# Altium schematic sheet Sheet2.SchDoc(Sheet2)
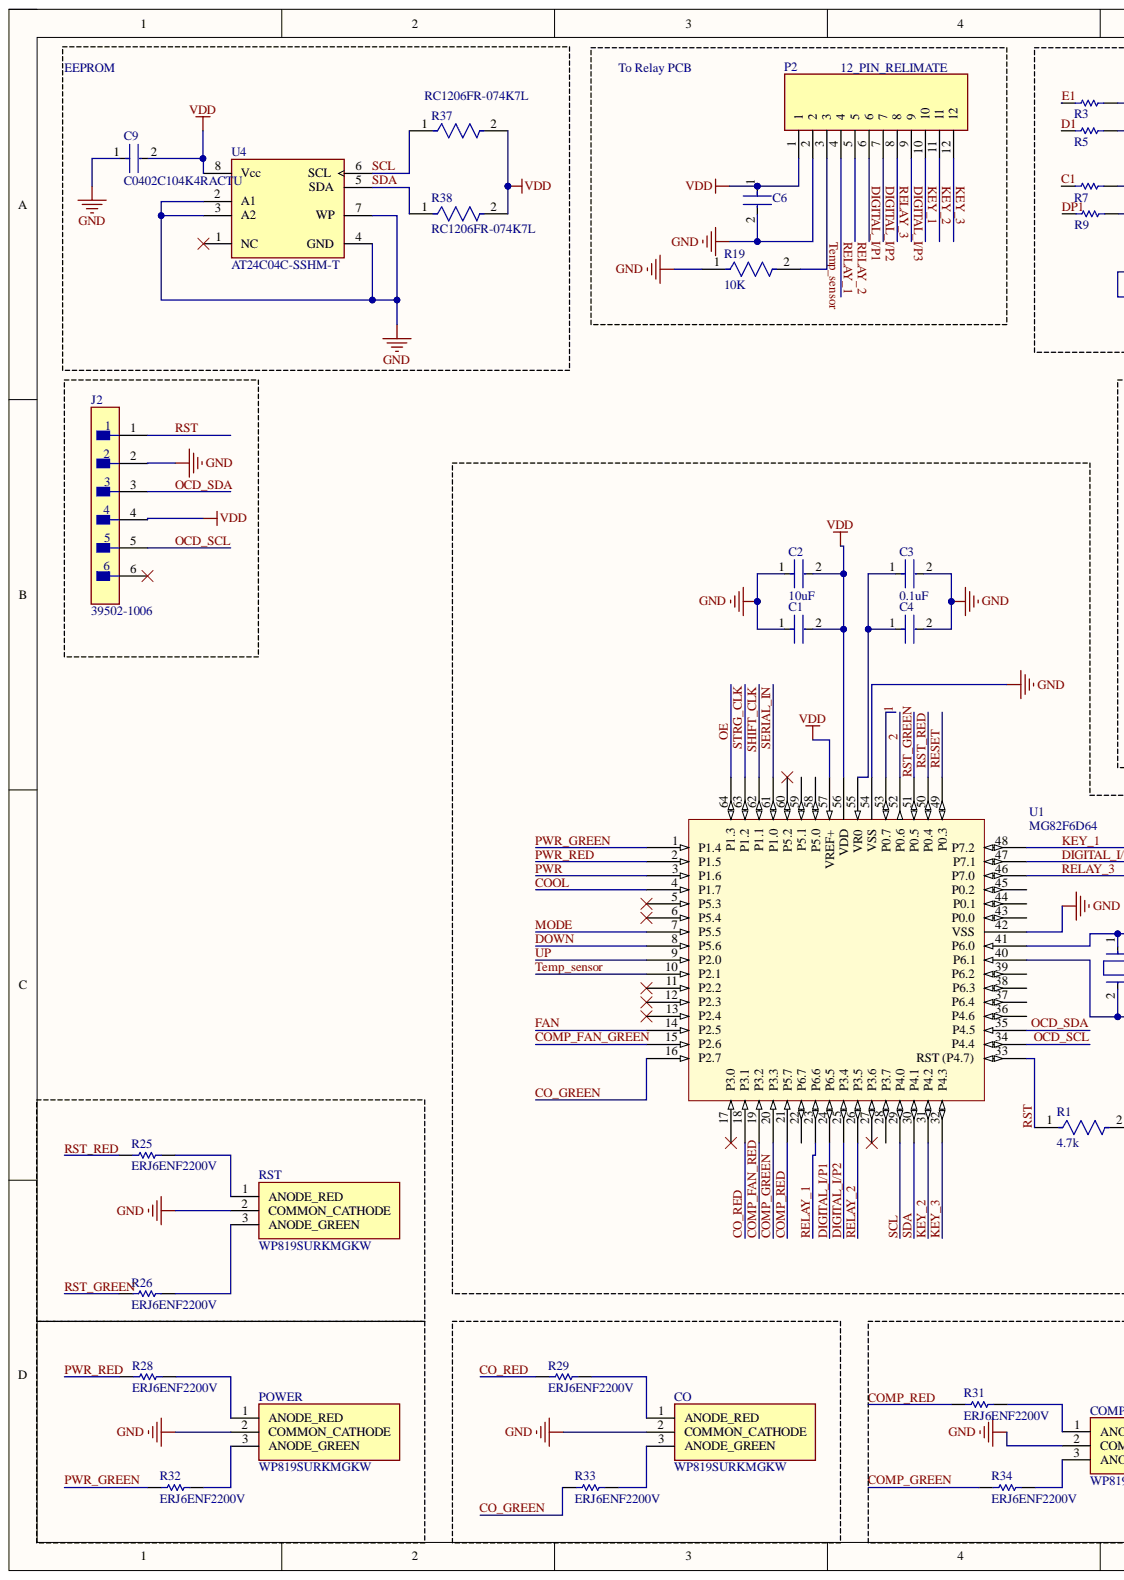
[diagram p0: Sheet2.SchDoc, left]
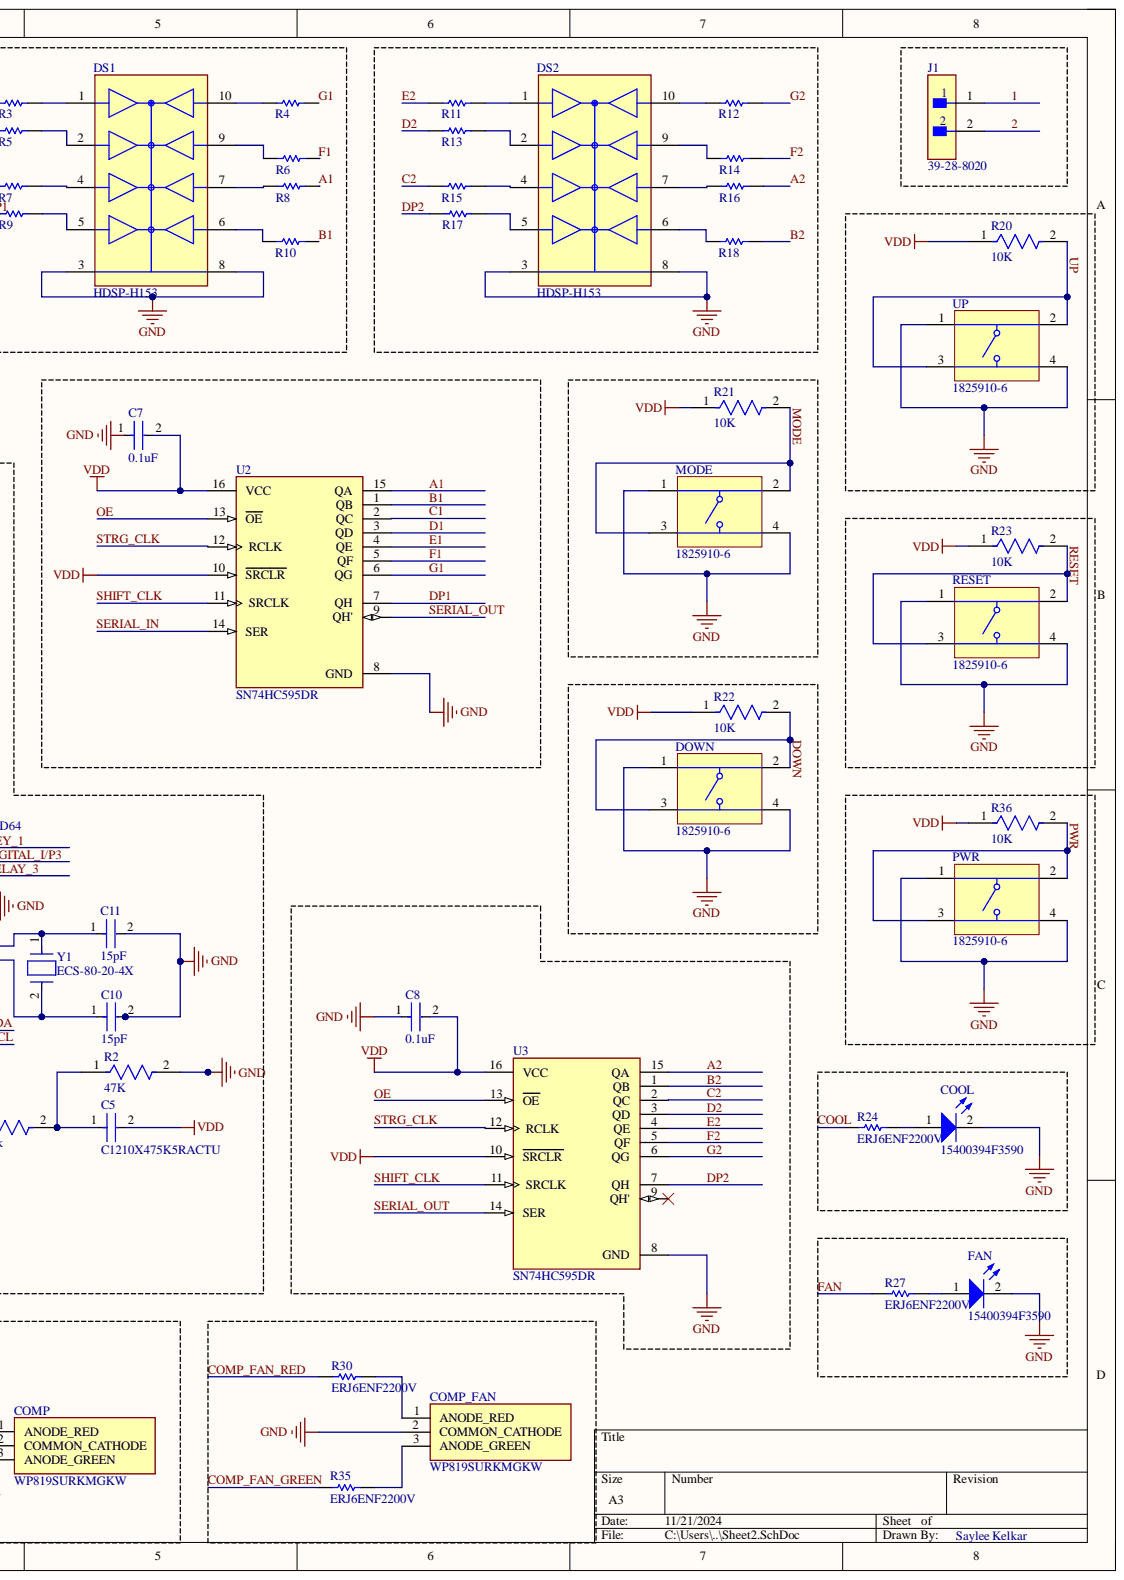
[diagram p1: Sheet2.SchDoc, right]

## Parts
C1  C0402C104K4RACTU  [FP-C0402-BB-MFG]
C2  10uF  [FP-GRM21B-0_15-IPC_C]
C3  0.1uF  [FP-C0402-BB-MFG]
C4  C0402C104K4RACTU  [FP-C0402-BB-MFG]
C5  C1210X475K5RACTU  [FP-C1210X475K5RACTU-MFG]
C6  C3216X5R1E476M160AC  [FP-C3216-160-0_2-MFG]  (value not drawn)
C7  0.1uF  [FP-C0402-BB-MFG]
C8  0.1uF  [FP-C0402-BB-MFG]
C9  C0402C104K4RACTU  [FP-C0402-BB-MFG]
C10  15pF  [FP-C1206C-EB-MFG]
C11  15pF  [FP-C1206C-EB-MFG]
CO  WP819SURKMGKW  [WP819SURKMGKW]
COMP  WP819SURKMGKW  [WP819SURKMGKW]
COMP_FAN  WP819SURKMGKW  [WP819SURKMGKW]
COOL  15400394F3590  [WL-TIRC_3mm]
DOWN  1825910-6  [TECO-1825910-6_V]
DS1  HDSP-H153  [FP-HDSP-H153-MFG]
DS2  HDSP-H153  [FP-HDSP-H153-MFG]
FAN  15400394F3590  [WL-TIRC_3mm]
J1  39-28-8020  [FP-39-28-8020-MFG]
J2  39502-1006  [FP-39502-1006-MFG]
MODE  1825910-6  [TECO-1825910-6_V]
P2  12_PIN_RELIMATE  [TE_1-644752-2]
POWER  WP819SURKMGKW  [WP819SURKMGKW]
PWR  1825910-6  [TECO-1825910-6_V]
R1  4.7k  [FP-CRCW0603-e3-IPC_A]
R2  47K  [FP-CRCW0603-e3-IPC_B]
R3  560 Ohm  [RESC1005X40X25LL05T05]  (value not drawn)
R4  560 Ohm  [RESC1005X40X25LL05T05]  (value not drawn)
R5  560 Ohm  [RESC1005X40X25LL05T05]  (value not drawn)
R6  560 Ohm  [RESC1005X40X25LL05T05]  (value not drawn)
R7  560 Ohm  [RESC1005X40X25LL05T05]  (value not drawn)
R8  560 Ohm  [RESC1005X40X25LL05T05]  (value not drawn)
R9  560 Ohm  [RESC1005X40X25LL05T05]  (value not drawn)
R10  560 Ohm  [RESC1005X40X25LL05T05]  (value not drawn)
R11  560 Ohm  [RESC1005X40X25LL05T05]  (value not drawn)
R12  560 Ohm  [RESC1005X40X25LL05T05]  (value not drawn)
R13  560 Ohm  [RESC1005X40X25LL05T05]  (value not drawn)
R14  560 Ohm  [RESC1005X40X25LL05T05]  (value not drawn)
R15  560 Ohm  [RESC1005X40X25LL05T05]  (value not drawn)
R16  560 Ohm  [RESC1005X40X25LL05T05]  (value not drawn)
R17  560 Ohm  [RESC1005X40X25LL05T05]  (value not drawn)
R18  560 Ohm  [RESC1005X40X25LL05T05]  (value not drawn)
R19  10K  [FP-CRCW0402-e3-IPC_B]
R20  10K  [FP-CRCW0402-e3-IPC_B]
R21  10K  [FP-CRCW0402-e3-IPC_B]
R22  10K  [FP-CRCW0402-e3-IPC_B]
R23  10K  [FP-CRCW0402-e3-IPC_B]
R24  ERJ6ENF2200V  [RESC2013X70X40NL20T20]
R25  ERJ6ENF2200V  [RESC2013X70X40NL20T20]
R26  ERJ6ENF2200V  [RESC2013X70X40NL20T20]
R27  ERJ6ENF2200V  [RESC2013X70X40NL20T20]
R28  ERJ6ENF2200V  [RESC2013X70X40NL20T20]
R29  ERJ6ENF2200V  [RESC2013X70X40NL20T20]
R30  ERJ6ENF2200V  [RESC2013X70X40NL20T20]
R31  ERJ6ENF2200V  [RESC2013X70X40NL20T20]
R32  ERJ6ENF2200V  [RESC2013X70X40NL20T20]
R33  ERJ6ENF2200V  [RESC2013X70X40NL20T20]
R34  ERJ6ENF2200V  [RESC2013X70X40NL20T20]
R35  ERJ6ENF2200V  [RESC2013X70X40NL20T20]
R36  10K  [FP-CRCW0402-e3-IPC_B]
R37  RC1206FR-074K7L  [FP-RC1206-0_65-IPC_B]
R38  RC1206FR-074K7L  [FP-RC1206-0_65-IPC_B]
RESET  1825910-6  [TECO-1825910-6_V]
RST  WP819SURKMGKW  [WP819SURKMGKW]
U1  MG82F6D64  [Quad64]
U2  SN74HC595DR  [FP-D0016A-MFG]
U3  SN74HC595DR  [FP-D0016A-MFG]
U4  AT24C04C-SSHM-T  [FP-C04-057-SN-MFG]
UP  1825910-6  [TECO-1825910-6_V]
Y1  ECS-80-20-4X  [FP-ECS-80-20-4X-MFG]

## Nets
GND: C1.1 C2.1 C3.2 C4.2 C6.2 C7.1 C8.1 C9.1 C10.2 C11.2 CO.2 COMP.2 COMP_FAN.2 COOL.2 DOWN.1 DOWN.4 DS1.3 DS1.8 DS2.3 DS2.8 FAN.2 J2.2 MODE.1 MODE.4 P2.2 POWER.2 PWR.1 PWR.4 R2.2 R19.1 RESET.1 RESET.4 RST.2 U1.42(VSS) U1.54(VSS) U2.8(GND) U3.8(GND) U4.2(A1) U4.3(A2) U4.4(GND) U4.7(WP) UP.1 UP.4
VDD: C1.2 C2.2 C5.2 C6.1 C7.2 C8.2 C9.2 J2.4 P2.1 R20.1 R21.1 R22.1 R23.1 R36.1 R37.2 R38.2 U1.56(VDD) U1.57(VREF+) U2.10(SRCLR) U2.16(VCC) U3.10(SRCLR) U3.16(VCC) U4.8(Vcc)
1: J1.1 U1.53(P0.7)
2: J1.2 U1.52(P0.6)
A1: R8.2 U2.15(QA)
A2: R16.2 U3.15(QA)
B1: R10.2 U2.1(QB)
B2: R18.2 U3.1(QB)
C1: R7.1 U2.2(QC)
C2: R15.1 U3.2(QC)
CO_GREEN: R33.1 U1.16(P2.7)
CO_RED: R29.1 U1.18(P3.1)
COMP_FAN_GREEN: R35.1 U1.15(P2.6)
COMP_FAN_RED: R30.1 U1.19(P3.2)
COMP_GREEN: R34.1 U1.20(P3.3)
COMP_RED: R31.1 U1.21(P5.7)
COOL: R24.1 U1.4(P1.7)
D1: R5.1 U2.3(QD)
D2: R13.1 U3.3(QD)
DIGITAL_I/P1: P2.6 U1.24(P6.5)
DIGITAL_I/P2: P2.7 U1.25(P3.4)
DIGITAL_I/P3: P2.9 U1.47(P7.1)
DOWN: DOWN.2 DOWN.3 R22.2 U1.8(P5.6)
DP1: R9.1 U2.7(QH)
DP2: R17.1 U3.7(QH)
E1: R3.1 U2.4(QE)
E2: R11.1 U3.4(QE)
F1: R6.2 U2.5(QF)
F2: R14.2 U3.5(QF)
FAN: R27.1 U1.14(P2.5)
G1: R4.2 U2.6(QG)
G2: R12.2 U3.6(QG)
KEY_1: P2.10 U1.48(P7.2)
KEY_2: P2.11 U1.31(P4.2)
KEY_3: P2.12 U1.32(P4.3)
MODE: MODE.2 MODE.3 R21.2 U1.7(P5.5)
OCD_SCL: J2.5 U1.34(P4.4)
OCD_SDA: J2.3 U1.35(P4.5)
OE: U1.64(P1.3) U2.13(OE) U3.13(OE)
PWR: PWR.2 PWR.3 R36.2 U1.3(P1.6)
PWR_GREEN: R32.1 U1.1(P1.4)
PWR_RED: R28.1 U1.2(P1.5)
RELAY_1: P2.4 U1.23(P6.6)
RELAY_2: P2.5 U1.26(P3.5)
RELAY_3: P2.8 U1.46(P7.0)
RESET: R23.2 RESET.2 RESET.3 U1.49(P0.3)
RST: J2.1 R1.1 U1.33(RST_(P4.7))
RST_GREEN: R26.1 U1.51(P0.5)
RST_RED: R25.1 U1.50(P0.4)
SCL: R37.1 U1.29(P4.0) U4.6(SCL)
SDA: R38.1 U1.30(P4.1) U4.5(SDA)
SERIAL_IN: U1.61(P1.0) U2.14(SER)
SERIAL_OUT: U2.9(QH') U3.14(SER)
SHIFT_CLK: U1.62(P1.1) U2.11(SRCLK) U3.11(SRCLK)
STRG_CLK: U1.63(P1.2) U2.12(RCLK) U3.12(RCLK)
Temp_sensor: P2.3 R19.2 U1.10(P2.1)
UP: R20.2 U1.9(P2.0) UP.2 UP.3
NetC3_1: C3.1 C4.1 U1.55(VR0)
NetC5_1: C5.1 R1.2 R2.1
NetC10_1: C10.1 U1.40(P6.1) Y1.2
NetC11_1: C11.1 U1.41(P6.0) Y1.1
NetCO_1: CO.1 R29.2
NetCO_3: CO.3 R33.2
NetCOMP_1: COMP.1 R31.2
NetCOMP_3: COMP.3 R34.2
NetCOMP_FAN_1: COMP_FAN.1 R30.2
NetCOMP_FAN_3: COMP_FAN.3 R35.2
NetCOOL_1: COOL.1 R24.2
NetDS1_1: DS1.1 R3.2
NetDS1_2: DS1.2 R5.2
NetDS1_4: DS1.4 R7.2
NetDS1_5: DS1.5 R9.2
NetDS1_6: DS1.6 R10.1
NetDS1_7: DS1.7 R8.1
NetDS1_9: DS1.9 R6.1
NetDS1_10: DS1.10 R4.1
NetDS2_1: DS2.1 R11.2
NetDS2_2: DS2.2 R13.2
NetDS2_4: DS2.4 R15.2
NetDS2_5: DS2.5 R17.2
NetDS2_6: DS2.6 R18.1
NetDS2_7: DS2.7 R16.1
NetDS2_9: DS2.9 R14.1
NetDS2_10: DS2.10 R12.1
NetFAN_1: FAN.1 R27.2
NetPOWER_1: POWER.1 R28.2
NetPOWER_3: POWER.3 R32.2
NetR25_2: R25.2 RST.1
NetR26_2: R26.2 RST.3

Not connected: J2 6; U1 5(P5.3) 6(P5.4) 11(P2.2) 12(P2.3) 13(P2.4) 17(P3.0) 22(P6.7) 27(P3.6) 28(P3.7) 36(P4.6) 37(P6.4) 38(P6.3) 39(P6.2) 43(P0.0) 44(P0.1) 45(P0.2) 58(P5.0) 59(P5.1) 60(P5.2); U3 9(QH'); U4 1(NC)

## Library data of the parts
C1 C4 C9 = CMP-2008-02108-2: CAP CER 0.1UF 16V X7R 0402; Manufacturer: Kemet; Capacitance: 0.10 μF; Material: Ceramic; Temperature Coefficient: 15%; Tolerance: 0.1; Value: 0.10 μF; Automotive: No; Category: Cap; Component Kind: Standard; ComponentLink2Description: Datasheet; ComponentLink2URL: https://content.kemet.com/datasheets/KEM_C1002_X7R_SMD.pdf; Device Class L1: Passive Components; Device Class L2: Capacitors; Device Class L3: Ceramic Capacitors; Digikey Description: CAP CER 0.1UF 16V X7R 0402; Height: 0.55mm; Ipc Land Pattern Name: CAPC10050X50; Lead Free: Yes; Library Name: Altium Content Vault; Manufacturer Part Number: C0402C104K4RACTU; Mouser Description: Cap Ceramic 0.1uF 16V X7R 10% SMD 0402 125?C Paper T/R - Tape and Reel (Alt: C0402C104K4RAC TU); Octopart: https://octopart.com/c0402c104k4ractu-kemet-94158; Package: C0402-BB; RoHS: TRUE; Temperature: -55°C to +125°C; Temperature Characteristic: X7R; Temperature Range High: +125°C; Temperature Range Low: -55°C; Voltage Rating: 16 V
C2 = CMP-2007-03541-2: Chip Multilayer Ceramic Capacitors for General Purpose, 0805, 10uF, X5R, 15%, 10%, 25V; Capacitance: 10uF; Case Code (Imperial): 0805; Case Code (Metric): 2012; Case/Package: 0805; Component Kind: Standard; Depth: 1.25mm; Dielectric: X5R; Height: 1.25mm; Lead Free: Lead Free; Length: 2mm; Library Name: Altium Content Vault; Material: Ceramic; Max Operating Temperature: 85°C; Min Operating Temperature: -55°C; Mount: Surface Mount; Package Quantity: 1; Packaging: Tape and Reel; Radiation Hardening: No; Termination: SMD/SMT; Thickness: 0.053inch; Tolerance: 10%; Voltage: 25V; Voltage Rating: 25V; Voltage Rating (DC): 25V; Width: 0.049inch
C3 C7 C8 = CMP-2008-02108-2: CAP CER 0.1UF 16V X7R 0402; Manufacturer: Kemet; Manufacturer Part Number: C0402C104K4RACTU; Capacitance: 0.10 μF; Material: Ceramic; Temperature Coefficient: 15%; Tolerance: 0.1; Value: 0.10 μF; Automotive: No; Category: Cap; Component Kind: Standard; ComponentLink2Description: Datasheet; ComponentLink2URL: https://content.kemet.com/datasheets/KEM_C1002_X7R_SMD.pdf; Device Class L1: Passive Components; Device Class L2: Capacitors; Device Class L3: Ceramic Capacitors; Digikey Description: CAP CER 0.1UF 16V X7R 0402; Height: 0.55mm; Ipc Land Pattern Name: CAPC10050X50; Lead Free: Yes; Library Name: Altium Content Vault; Mouser Description: Cap Ceramic 0.1uF 16V X7R 10% SMD 0402 125?C Paper T/R - Tape and Reel (Alt: C0402C104K4RAC TU); Octopart: https://octopart.com/c0402c104k4ractu-kemet-94158; Package: C0402-BB; RoHS: TRUE; Temperature: -55°C to +125°C; Temperature Characteristic: X7R; Temperature Range High: +125°C; Temperature Range Low: -55°C; Voltage Rating: 16 V
C5 = CMP-2000-06022-2: CAP CER 4.7UF 50V X7R 1210; Dielectric Material: Ceramic; Dissipation Factor: 2.5%; Tolerance: 10%; Capacitance: 4.7uF; Case Code (Imperial): 1210; Case Code (Metric): 3225; Case/Package: 1210; Component Kind: Standard; Construction: Flat; Depth: 2.5mm; Dielectric: X7R; Features: Soft Termination; Height: 2.5mm; Length: 3.3mm; Library Name: Altium Content Vault; Max Operating Temperature: 125°C; Min Operating Temperature: -55°C; Mount: Surface Mount; Number of Pins: 2; Package Quantity: 1000; Packaging: Tape and Reel; Radiation Hardening: No; REACH SVHC: No SVHC; RoHS Compliant: Yes; Series: FT-CAP; Termination: SMD/SMT; Thickness: 0.11inch; Voltage: 50V; Voltage Rating: 50V; Voltage Rating (DC): 50V; Width: 2.5mm
C6 = CMP-2000-05397-3: Multilayer Ceramic Capacitors 47uF ±20% 25V X5R SMD 1206; Capacitance: 47uF; Case Code (Imperial): 1206; Case Code (Metric): 3216; Case/Package: 1206; Component Kind: Standard; Depth: 1.6mm; Dielectric: X5R; Dielectric Material: Ceramic; Height: 1.6mm; Lead Free: Lead Free; Length: 0.126inch; Library Name: Altium Content Vault; Max Operating Temperature: 85°C; Min Operating Temperature: -55°C; Mount: Surface Mount; Package Quantity: 1; Packaging: Tape and Reel; REACH SVHC: No SVHC; Resistor Type: Ceramic; RoHS Compliant: Yes; Series: C; Termination: SMD/SMT; Thickness: 0.071inch; Tolerance: 20%; Voltage: 25V; Voltage Rating: 25V; Voltage Rating (DC): 25V; Weight: 0.000571oz; Width: 0.063inch
C10 C11 = CMP-1037-00805-2: 1206 C 15pF Ceramic Multilayer Capacitor; 50 VDC; +125degC; C0G Dielec; +/-5%; Manufacturer: KEMET; Manufacturer Part Number: C1206C150J5GACTU; Automotive Grade: Grade 0; Temperature Coefficient: 15%; Tolerance: 0.05; Voltage Rating: 50 V; Automotive: Yes; Capacitance: 15 pF; Category: Cap; Component Kind: Standard; ComponentLink2Description: Datasheet; ComponentLink2URL: https://content.kemet.com/datasheets/KEM_C1007_X8R_ULTRA_150C_SMD.pdf; Device Class L1: Passive Components; Device Class L2: Capacitors; Device Class L3: Ceramic Capacitors; Digikey Description: 1206 C 15pF Ceramic Multilayer Capacitor; 50 VDC; +125degC; C0G Dielec; +/-5%; Height: 0.88mm; Ipc Land Pattern Name: CAPC320160X78; Lead Free: Yes; Library Name: Altium Content Vault; Material: Ceramic; Mouser Description: Multilayer Ceramic Capacitors MLCC - SMD/SMT 50volts 15pF C0G 5%; Octopart: https://octopart.com/c1206c150j5gactu-kemet-138585; Package: C1206C-EB; RoHS: TRUE; Temperature: -55°C to +150°C; Temperature Characteristic: C0G; Temperature Range High: +150°C; Temperature Range Low: -55°C; Value: 15 pF
CO COMP COMP_FAN POWER RST = WP819SURKMGKW: Standard LEDs - Through Hole Red Green 630 570nm Diffused 750 130mcd; Manufacturer_Name: Kingbright; Geometry.Height: 14.75mm; Component Kind: Standard; Datasheet Link: https://www.kingbrightusa.com/images/catalog/SPEC/WP819SURKMGKW.pdf; Library Name: WP819SURKMGKW.SchLib; Manufacturer_Part_Number: WP819SURKMGKW; Mouser Part Number: 604-WP819SURKMGKW; Mouser Price/Stock: https://www.mouser.co.uk/ProductDetail/Kingbright/WP819SURKMGKW?qs=58z0TXQGVSRLNoI%2FJv9TJg%3D%3D
COOL FAN = CMP-03913-110288039-1: 15400394F3590 Led, 3Mm, 940Nm, 30Mw/Sr, Th Rohs Compliant: Yes; Forward Current: 1A; Forward Voltage: 1.3V; Max Reverse Voltage (DC): 5V; Viewing Angle: 30°; Component Kind: Standard; Library Name: Altium Content Vault; Max Operating Temperature: 85°C; Min Operating Temperature: -40°C; NexarPartId: 110288039; Peak Wavelength: 940nm; RoHS: Compliant
  COOL: Simulation: C_Capacitor_Default
DOWN MODE PWR RESET UP = CMP-1684-00021-1: Tact Switch, SPST-NO, 0.05 A, -35 to 85 degC, 4-Pin THD, RoHS, Bulk; Manufacturer: TE Connectivity; Actuator Color: Black; Actuator Length: 1.4mm; Actuator Material: PolybutyleneTerephthalate; Actuator Style: RoundButton; Body Orientation: Straight; Bushing Type: Unthreaded; Contact Rating @ Voltage: 0.05A@24VDC; Dielectric Strength: 500VAC; Electrical Life: 100000Cycles; Function: MomentaryContact; Illumination: N/R; Maximum Contact Resistance: 100mOhm; Maximum DC Voltage Rating: 24V; Pole Throw Configuration: SPST; Switch Configuration: OFF(ON); Base Material: N/A; Component Kind: Standard; Contact Material: CopperAlloy; Contact Plating: Silver; Datasheet URL: http://www.te.com/commerce/DocumentDelivery/DDEController?Action=showdoc&DocId=Customer+Drawing%7F1825910%7FC9%7Fpdf%7FEnglish%7FENG_CD_1825910_C9.pdf%7F1825910-6; Datasheet Version: Rev. C9; Dimension: 5.99x5mm; Family: 1825910; Housing Material: N/A; Library Name: Altium Content Vault; Manufacturer URL: http://www.te.com; Maximum AC Voltage Rating: N/A; Mechanical Life: 100000Cycles; Minimum Insulation Resistance: 100MOhm; Mounting: ThroughHole; Operating Temperature: -35to85C; Package Description: 4-Pin Through Hole Device, Body 5.99 x 5.99 mm, Pitch 6.5 mm; Package Reference: THD; Packaging: N/A; Part No: 1825910-6; Product Weight: N/A; RoHS: Yes; Seal Description: N/A; Seal Location: N/A; Terminal Type: PCPins; Thread Size: N/R
  RESET: Simulation: R_Resistor_Default
DS1 DS2 = CMP-18395-000002-1: LED 7-SEG 14.2MM CC RED RHD RHD; Manufacturer: Broadcom; Element Config: Common Cathode; Forward Current: 100mA; Forward Voltage: 2V; Luminous Intensity: 16mcd; Power Dissipation: 96mW; Reverse Voltage: 15V; Standoff Height: 1.14mm; Viewing Angle: 100°; Wavelength: 637nm; Automotive: No; Category: Disp; Component Kind: Standard; ComponentLink2Description: Datasheet; ComponentLink2URL: https://docs.broadcom.com/docs/AV02-1107EN; Device Class L1: Optoelectronics; Device Class L2: Displays; Device Class L3: LED Displays; Digikey Description: LED 7-SEG 14.2MM CC RED RHD RHD; Display Type: LED; Height: 8mm; Lead Free: Yes; Library Name: Altium Content Vault; Manufacturer Part Number: HDSP-H153; Octopart: https://octopart.com/hdsp-h153-broadcom-71129578; Package: HDSP-H153; RoHS: TRUE; Temperature: -20°C to +100°C; Temperature Range High: +100°C; Temperature Range Low: -20°C; Test Current: 100mA
J1 = CMP-04776-000089-1: CONN HEADER VERT 2POS; Manufacturer: Molex; Conn Gender: Male; Conn Orientation: Vertical; Current Rating: 9A; Number Of Contacts: 2; Voltage Rating: 600V; Automotive: No; Category: Conn; Component Kind: Standard; ComponentLink2Description: Datasheet; ComponentLink2URL: https://www.molex.com/webdocs/datasheets/pdf/en-us/0039288020_PCB_HEADERS.pdf; Contact Material: Tin,Nickel; Device Class L1: Connectors; Device Class L2: Headers and Wire Housings; Device Class L3: unset; Digikey Description: CONN HEADER VERT 2POS; Footprint Url: https://www.molex.com/pdm_docs/sd/039288020_sd.pdf; Height: 13.1mm; Lead Free: Yes; Library Name: Altium Content Vault; Manufacturer Part Number: 39-28-8020; Mouser Description: Connector Header 2 Position Solder Straight Thru-Hole 2 Terminal 1 Port Mini-Fit Jr™; Number Of Rows: 2; Octopart: https://octopart.com/39-28-8020-molex-277453; Package: 39-28-8020; RoHS: TRUE; Temperature: -40°C to +105°C; Temperature Range High: +105°C; Temperature Range Low: -40°C
J2 = CMP-00257-00655372-1: 39502-1006 Conn Shrouded Header (4 Sides) HDR 6 POS 3.5mm Solder RA Side Entry Thru-Hole Eurostyle™; Manufacturer Lifecycle Status: ACTIVE; NexarPartId: 655372; Contact Resistance: 8mΩ; Current: 8A; Gender: Male; Number of Circuits: 5; Number of Contacts: 6; Number of Levels: 1; Voltage: 300V; Color: Black; Component Kind: Standard; Contact Material: Brass; Contact Plating: Tin; Country of Origin: US; Current Rating: 8A; Depth: 9.2mm; Housing Color: Black; Housing Material: Polyamide; Lead Free: Lead Free; Length: 22.39mm; Library Name: Altium Content Vault; Lifecycle Status: Production; Max Operating Temperature: 105°C; Min Operating Temperature: -40°C; Mount: Through Hole; Number of Positions: 6; Number of Positions Per Level: 6; Number of Rows: 1; Orientation: Horizontal; Packaging: Bulk; Pitch: 3.5mm; RoHS: Compliant; Termination: Solder
P2 = 1-644752-2: Availability: Unavailable; Component Kind: Standard; Library Name: 1-644752-2.IntLib; MP: 1-644752-2; Package: None; Price: None
R1 = CMP-1666-00019-3: RES Thick Film, 4.7kΩ, 1%, 0.1W, 100ppm/°C, 0603; Power Rating: 100mW; Resistance: 4.7kR; Voltage Rating: 75V; Case Code (Imperial): 0603; Case Code (Metric): 1608; Case/Package: 0603; Component Kind: Standard; Composition: Thick Film; Contact Plating: Tin; Depth: 0.85mm; Height: 0.45mm; Lead Free: Lead Free; Length: 1.55mm; Library Name: Altium Content Vault; Max Operating Temperature: 155°C; Military Standard: Not; Min Operating Temperature: -55°C; Mount: Surface Mount; Package Quantity: 5000; Packaging: Tape and Reel; Temperature Coefficient: 100ppm/°C; Termination: SMD/SMT; Tolerance: 1%; Voltage Rating (DC): 75V; Weight: 7.1E-05oz; Width: 0.85mm
R2 = CMP-2000-03379-3: RES SMD 47K OHM 1% 1/10W 0603; Max Power Dissipation: 100mW; Resistance: 47kR; Temperature Coefficient: 100ppm/°C; Voltage Rating: 75V; Case Code (Imperial): 0603; Case Code (Metric): 1608; Case/Package: 0603; Component Kind: Standard; Construction: Rectangular; Contact Plating: Tin; Depth: 0.85mm; Features: Automotive AEC-Q200; Height: 0.45mm; Lead Free: Lead Free; Length: 1.55mm; Library Name: Altium Content Vault; Max Operating Temperature: 155°C; Military Standard: Not; Min Operating Temperature: -55°C; Mount: Surface Mount; Number of Terminations: 2; Package Quantity: 5000; Packaging: Tape and Reel; Power Rating: 100mW; Radiation Hardening: No; Resistance Tolerance: 1%; Resistor Type: Thick Film; RoHS Compliant: Yes; Series: CRCW; Size Code: 0603; Technology: THICK FILM; Termination: SMD/SMT; Tolerance: 1%; Voltage Rating (DC): 75V; Weight: 7.1E-05oz; Width: 0.85mm
R3-R18 = CMP-2002-01159-2: Chip Resistor, 560 Ohm, +/- 1%, 0.1 W, -55 to 155 degC, 0402 (1005 Metric), RoHS, Tape and Reel; Case\Package: 0402; Power Rating: 100 mW; Voltage Rating: 50 V; Case Code (Imperial): 0402; Case Code (Metric): 1005; Component Kind: Standard; ComponentLink1Description: Manufacturer URL; ComponentLink1URL: http://www.panasonic.com/; ComponentLink2Description: Datasheet; ComponentLink2URL: http://industrial.panasonic.com/cdbs/www-data/pdf/RDA0000/AOA0000C86.pdf; DatasheetVersion: 04/2015; Height: 0.35 mm; Length: 1 mm; Library Name: Altium Content Vault; Max Operating Temperature: 155 degC; Min Operating Temperature: -55 degC; Mount: Surface Mount; Mounting Technology: Surface Mount; Package Quantity: 10000; PackageDescription: 2-Pin Surface Mount Device, Body 1 x 0.5 mm; PackageReference: 0402; Packaging: Tape and Reel; Pins: 2; Radiation Hardening: No; REACH SVHC: Yes; Resistance: 560 Ohm; RoHSCompliant: true; Tolerance: 1%; Width: 0.5 mm
R19-R23 R36 = CMP-2002-08135-2: RES SMD 10K OHM 1% 1/16W 0402; Max Power Dissipation: 63mW; Resistance: 10kR; Temperature Coefficient: 100ppm/°C; Voltage Rating: 50V; Case Code (Imperial): 0402; Case Code (Metric): 1005; Case/Package: 0402; Component Kind: Standard; Composition: Thick Film; Contact Plating: Tin; Depth: 0.5mm; Features: Automotive AEC-Q200; Height: 0.35mm; Lead Free: Lead Free; Length: 1mm; Library Name: Altium Content Vault; Max Operating Temperature: 155°C; Military Standard: Not; Min Operating Temperature: -55°C; Mount: Surface Mount; Number of Pins: 2; Number of Terminations: 2; Package Quantity: 10; Packaging: Tape and Reel; Power Rating: 63mW; Radiation Hardening: No; Termination: SMD/SMT; Tolerance: 1%; Voltage Rating (DC): 50V; Weight: 2.3E-05oz; Width: 0.02inch
R24-R35 = CMP-2001-00044-1: Manufacturer: Panasonic; Component Kind: Standard; Library Name: Altium Content Vault; Part Number: ERJ6ENF2200V
R37 R38 = CMP-03412-037805-1: RES SMD 4.7K OHM 1% 1/4W 1206; Max Power Dissipation: 250mW; Resistance: 4.7kR; Temperature Coefficient: 100ppm/°C; Voltage Rating: 200V; Case Code (Imperial): 1206; Case Code (Metric): 3216; Case/Package: 1206; Component Kind: Standard; Construction: Rectangular; Depth: 1.6mm; Features: Moisture Resistant; Height: 0.026inch; Lead Free: Lead Free; Length: 0.122inch; Library Name: Altium Content Vault; Max Operating Temperature: 155°C; Military Standard: Not; Min Operating Temperature: -55°C; Number of Pins: 2; Number of Terminations: 2; Package Quantity: 1; Packaging: Tape and Reel; Power Rating: 250mW; Resistance Tolerance: 1%; Resistor Type: Thick Film; RoHS Compliant: Yes; Series: RC; Size Code: 1206; Termination: SMD/SMT; Tolerance: 1%; Voltage Rating (DC): 200V; Width: 0.063inch
U2 U3 = CMP-0780-00037-4: IC 8BIT SHIFT REG 3ST-OUT 16SOIC; Manufacturer: Texas Instruments; Frequency: 29MHz; Max Junction Temp: +150°C; Max Supply Voltage: 6V; Min Supply Voltage: 2V; Nominal Supply Current: 80uA; Number Of Circuits: 1; Number Of Outputs: 9; Propagation Delay: 43ns; Standoff Height: 0.1mm; Ambient Temperature Range High: +85°C; Ambient Temperature Range Low: -40°C; Automotive: No; Category: IC; Component Kind: Standard; ComponentLink2Description: Datasheet; ComponentLink2URL: http://www.ti.com/lit/ds/symlink/sn74hc595.pdf; Device Class L1: Integrated Circuits (ICs); Device Class L2: Logic ICs; Device Class L3: Shift Registers; Digikey Description: IC 8BIT SHIFT REG 3ST-OUT 16SOIC; Height: 1.75mm; Ipc Land Pattern Name: SOIC127P600X175-16; Lead Free: Yes; Library Name: Altium Content Vault; Manufacturer Part Number: SN74HC595DR; Mouser Description: Counter Shift Registers 8bit Shift; Number Of Inputs: 1; Octopart: https://octopart.com/sn74hc595dr-texas+instruments-500634; Package: D0016A; RoHS: TRUE; Temperature: -40°C to +85°C; Temperature Range High: +85°C; Temperature Range Low: -40°C
U4 = CMP-06313-000074-1: IC EEPROM 4K I2C 1MHZ 8SOIC; Access Time: 550ns; Memory Size: 4Kb; Memory Type: Non-Volatile; Case/Package: SOIC; Component Kind: Standard; Frequency: 1MHz; Library Name: Altium Content Vault; Max Operating Temperature: 85°C; Min Operating Temperature: -40°C; Mount: Surface Mount; Number of Pins: 8; Packaging: Tape and Reel; RoHS Compliant: Yes
Y1 = CMP-1669-00002-3: NexarPartId: 316504; ESR (Equivalent Series Resistance): 80Ω; Frequency: 8MHz; Frequency Stability: 50ppm; Frequency Tolerance: 0.003%; Load Capacitance: 20pF; Component Kind: Standard; Height: 3.5mm; Lead Free: Lead Free; Length: 11.3538mm; Library Name: Altium Content Vault; Max Operating Temperature: 70°C; Min Operating Temperature: -10°C; Mount: Through Hole; Number of Pins: 49; Packaging: Bulk; RoHS: Compliant; Schedule B: 8541600025, 8541600025; Termination: Radial; Tolerance: 0.003%; Width: 4.65mm
U1 = MG82F6D64: Component Kind: Standard; Library Name: Schlib1.SchLib
